# Altium schematic sheet Generator_1kHz.SchDoc
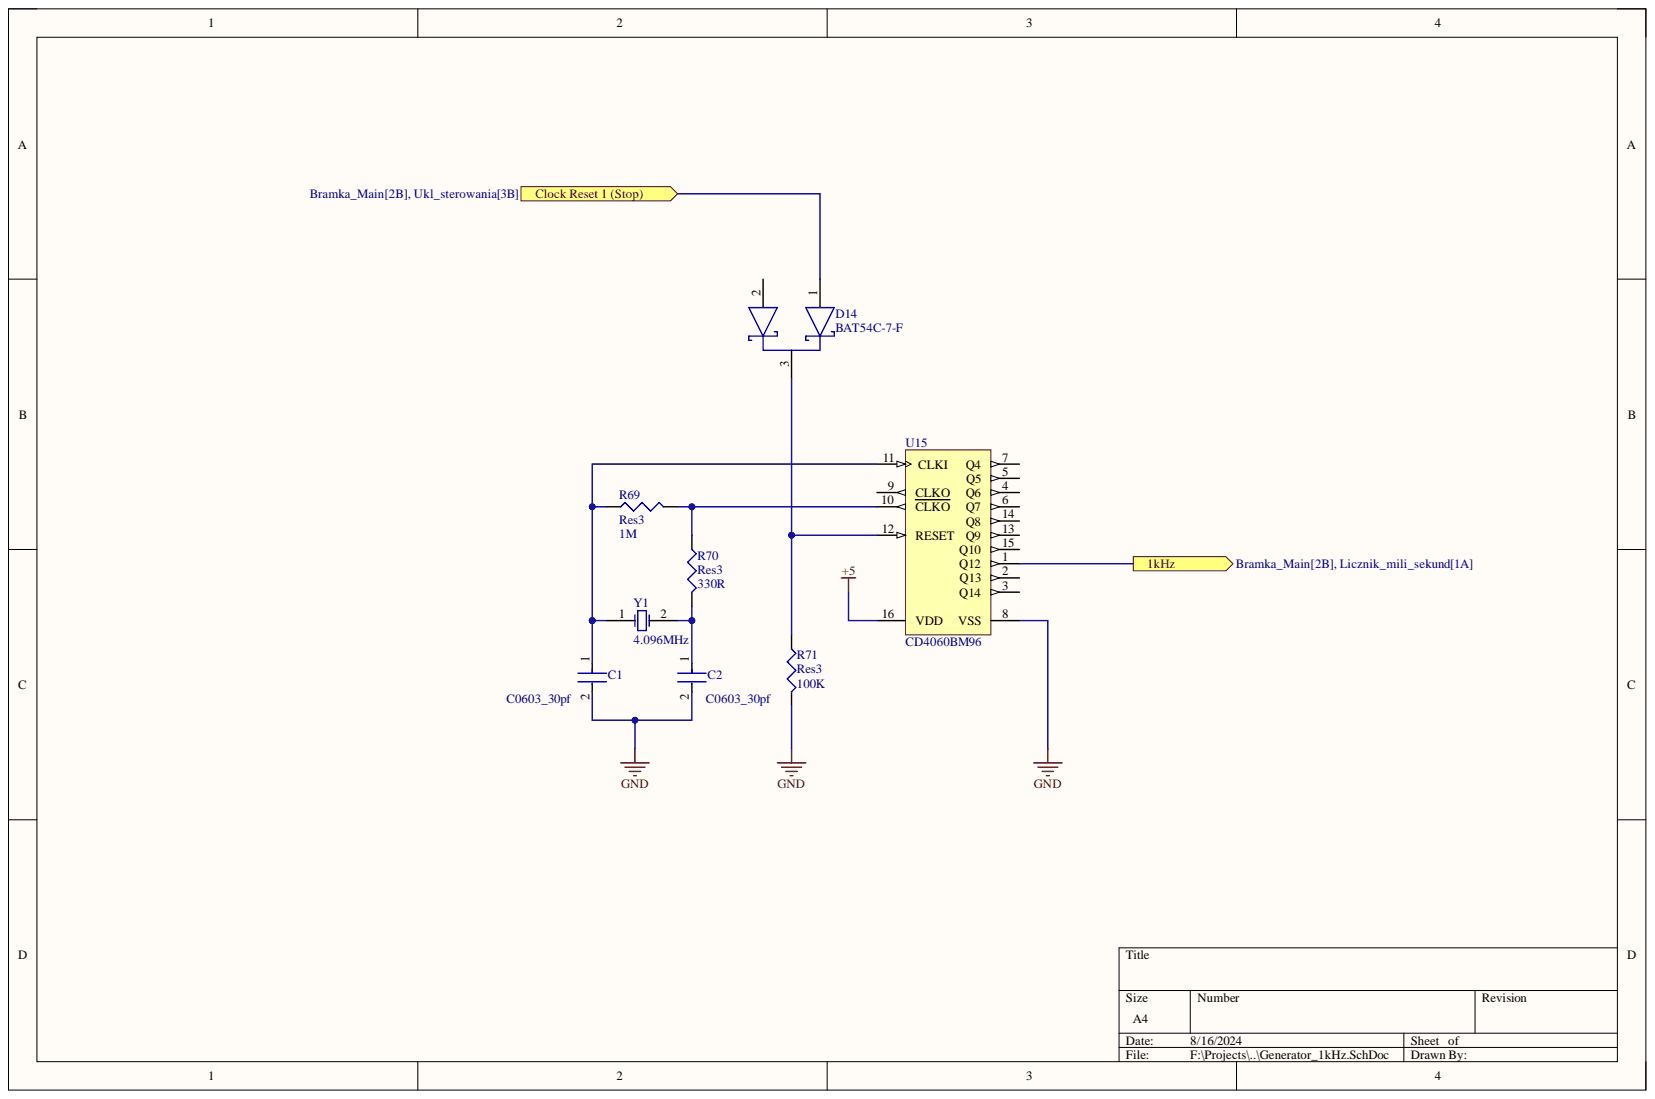
[diagram p0: Generator_1kHz.SchDoc]

## Parts
C1  C0603_30pf  [FP-C0603C-CF-MFG]
C2  C0603_30pf  [FP-C0603C-CF-MFG]
D14  BAT54C-7-F  [FP-SOT23-IPC_B]
R69  Res3  value 1M  [J1-0603]
R70  Res3  value 330R  [J1-0603]
R71  Res3  value 100K  [J1-0603]
U15  CD4060BM96  [D0016A_L]
Y1  4.096MHz  [R38]

## Ports
1kHz, Clock Reset 1 (Stop)

## Nets
+5: U15.16(VDD)
GND: C1.2 C2.2 R71.2 U15.8(VSS)
1kHz: U15.1(Q12) ; port 1kHz
Clock Reset 1 (Stop): D14.1 ; port Clock Reset 1 (Stop)
NetC1_1: C1.1 R69.1 U15.11(CLKI) Y1.1
NetC2_1: C2.1 R70.2 Y1.2
NetD14_2: D14.2
NetD14_3: D14.3 R71.1 U15.12(RESET)
NetR69_2: R69.2 R70.1 U15.10(CLKO)
NetU15_2: U15.2(Q13)
NetU15_3: U15.3(Q14)
NetU15_4: U15.4(Q6)
NetU15_5: U15.5(Q5)
NetU15_6: U15.6(Q7)
NetU15_7: U15.7(Q4)
NetU15_9: U15.9(CLKO)
NetU15_13: U15.13(Q9)
NetU15_14: U15.14(Q8)
NetU15_15: U15.15(Q10)

## Library data of the parts
C1 C2 = CMP-1035-00914-3: CAP CER 30PF 50V 5% NP0 0603; Manufacturer: KEMET; Manufacturer Part Number: C0603C300J5GACTU; Automotive Grade: Grade 1; Material: Ceramic; Temperature Characteristic: C0G; Temperature Coefficient: 30ppm/°C; Tolerance: 0.05; Automotive: Yes; Capacitance: 30 pF; Category: Cap; Component Kind: Standard; ComponentLink2Description: Datasheet; ComponentLink2URL: https://api.kemet.com/component-edge/download/datasheet/C0603C100J5GACAUTO.pdf; Device Class L1: Passive Components; Device Class L2: Capacitors; Device Class L3: Ceramic Capacitors; Digikey Description: CAP CER 30PF 50V 5% NP0 0603; Height: 0.87mm; Lead Free: Yes; Library Name: Altium Content Vault; Mouser Description: Multilayer Ceramic Capacitors MLCC - SMD/SMT 50volts 30pF C0G 5%; Octopart: https://octopart.com/c0603c300j5gactu-kemet-199455; Package: C0603C-CF; RoHS: TRUE; Temperature: -55°C to +125°C; Temperature Range High: +125°C; Temperature Range Low: -55°C; Value: 30 pF; Voltage Rating: 50 V
D14 = CMP-12790-000084-1: DIODE ARRAY SCHOTTKY 30V SOT23-3; Average Rectified Current: 200mA; Capacitance: 10pF; Element Configuration: Common Cathode; Forward Voltage: 800mV; Max Forward Surge Current (Ifsm): 600mA; Max Power Dissipation: 200mW; Max Reverse Current: 2uA; Reverse Recovery Time: 5ns; Case/Package: SOT-23; Component Kind: Standard; Contact Plating: Tin; Current Rating: 200mA; Forward Current: 200mA; Height: 1mm; Lead Free: Lead Free; Length: 2.9mm; Library Name: Altium Content Vault; Max Operating Temperature: 150°C; Max Repetitive Reverse Voltage (Vrrm): 30V; Max Reverse Voltage (DC): 30V; Min Operating Temperature: -65°C; Mount: Surface Mount; Number of Pins: 3; Package Quantity: 3000; Packaging: Tape and Reel; Peak Non-Repetitive Surge Current: 600mA; Power Dissipation: 200mW; Power Rating: 200mW; Radiation Hardening: No; REACH SVHC: No SVHC; RoHS Compliant: Yes; Series: -; Termination: SMD/SMT; Voltage Rating (DC): 30V; Weight: 0.000282oz; Width: 1.3mm
R69-R71 = Res3: Resistor; Component Kind: Standard; LatestRevisionDate: 29-May-2009; LatestRevisionNote: IPC-7351 Footprint Added.; Library Name: Miscellaneous Devices.IntLib; PackageDescription: Chip Resistor; PackageReference: J1-0603; Published: 8-Jun-2000; Signal Integrity: Res; Simulation: RESISTOR; Publisher: Altium Limited
U15 = CMP-1086-00004-4: CMOS 14-Stage Ripple Carry Binary Counter / Divider and Oscillator, 3 to 18 V, -55 to 125 degC, 16-Pin SOIC (D), Green (RoHS & no Sb/Br), Tape and Reel; Manufacturer: Texas Instruments; tPHL, tPLH (typ) @ 5 V: 370 ns; VDD: 3 to 18 V; Component Kind: Standard; ComponentLink1Description: Manufacturer URL; ComponentLink1URL: http://www.ti.com; ComponentLink2Description: Datasheet; ComponentLink2URL: http://www.ti.com/lit/ds/symlink/cd4060b.pdf; ComponentLink3Description: Package Specification; ComponentLink3URL: http://www.ti.com/lit/ml/mpds178g/mpds178g.pdf; DatasheetVersion: SCHS049C; Library Name: Altium Content Vault; Mounting Technology: Surface Mount; Note1: Green (RoHS & no Sb/Br); PackageDescription: 16-Pin Plastic Small-Outline Package, Body 9.9 x 3.9 mm, Pitch 1.27 mm; PackageReference: D0016A; PackageVersion: 4040047-6/M; Packing: Tape and Reel; PartNumber: CD4060BM96; Temperature Range: -55 to 125 degC
Y1 = XTAL: Crystal Oscillator; Component Kind: Standard; LatestRevisionDate: 17-Jul-2002; LatestRevisionNote: Re-released for DXP Platform.; Library Name: Miscellaneous Devices.IntLib; PackageDescription: Crystal, Thru-Hole; 2 Leads; Body 3.1 x 8.2 mm (Dia.xH); PackageReference: R38; Published: 8-Jun-2000; Simulation: XTAL; Publisher: Altium Limited
